# Altium schematic sheet Analog Input.SchDoc
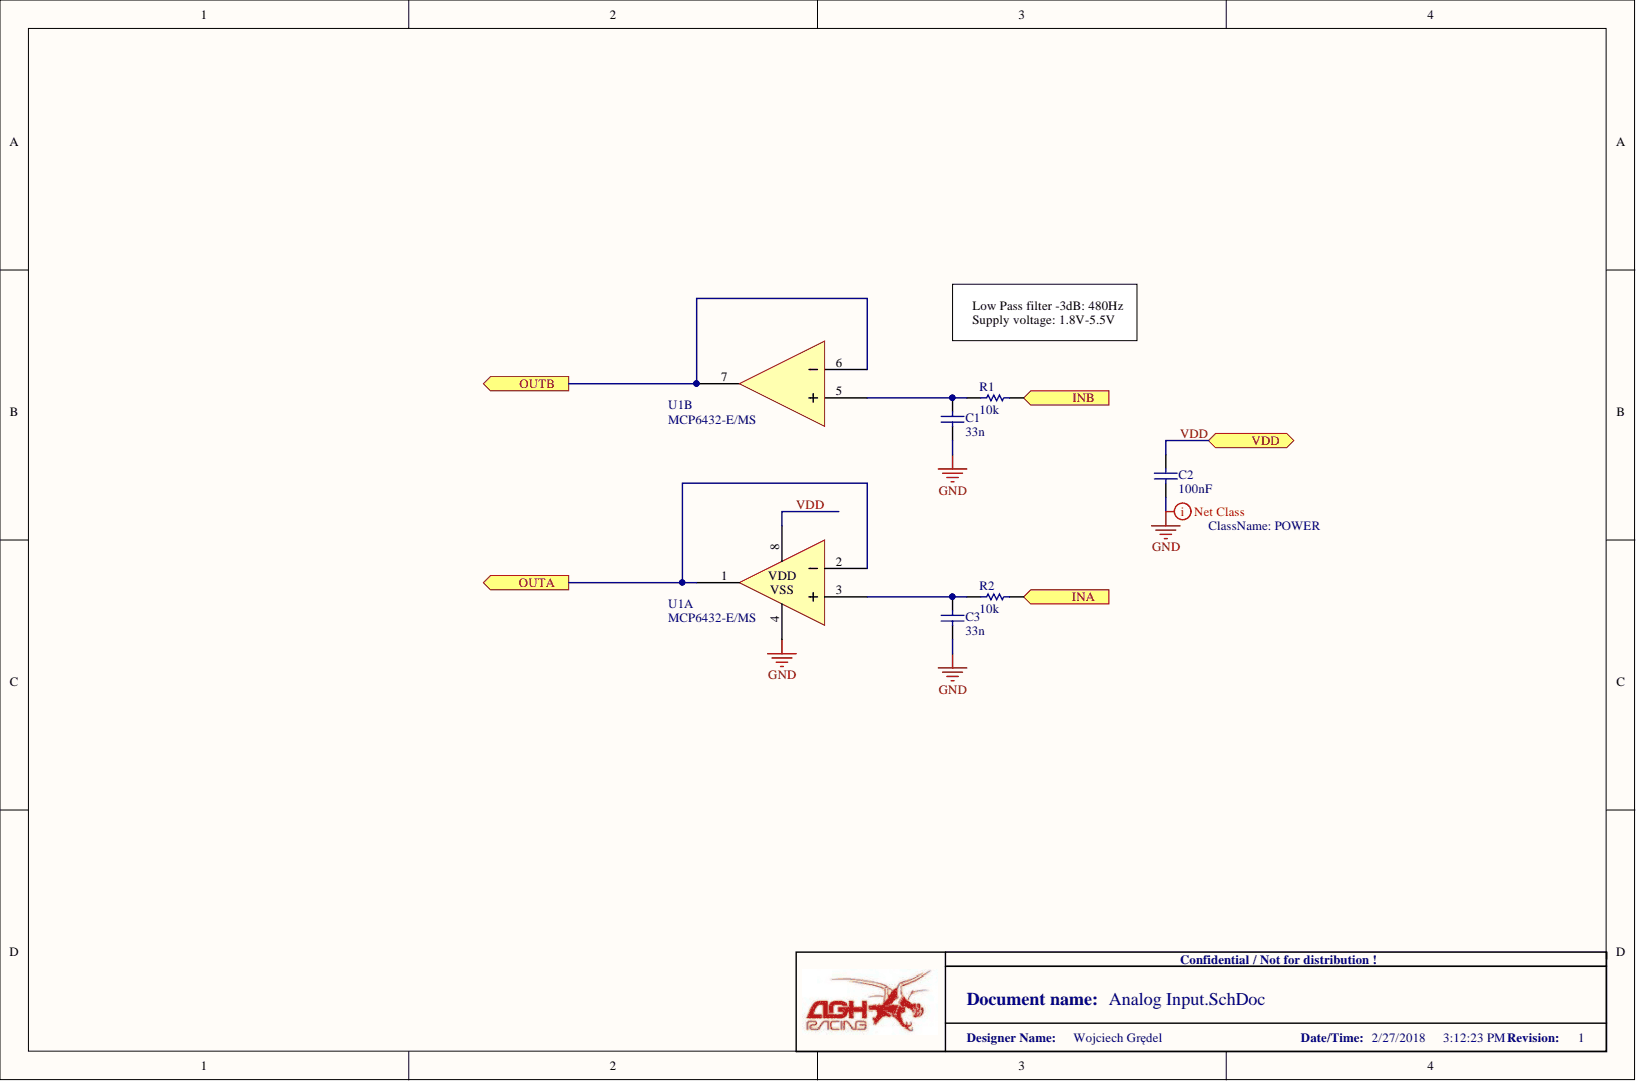
[diagram p0: Analog Input.SchDoc]

## Parts
C1  value 33n  [CAP_0603_IPC_HD]  (hidden comment: Cap)
C2  value 100nF  [CAP_0603_IPC_HD]  (hidden comment: Cap)
C3  value 33n  [CAP_0603_IPC_HD]  (hidden comment: Cap)
R1  value 10k  [RES_0603_IPC_HD]  (hidden comment: Res)
R2  value 10k  [RES_0603_IPC_HD]  (hidden comment: Res)
U1  MCP6432-E/MS  [MSOP8_IPC_MD]  (parts A,B)

## Ports
INA, INB, OUTA, OUTB, VDD

## Nets
GND: C1.1 C2.1 C3.1 U1.4
INA: R2.2 ; port INA
INB: R1.2 ; port INB
OUTA: U1.1 U1.2 ; port OUTA
OUTB: U1.6 U1.7 ; port OUTB
VDD: C2.2 U1.8 ; port VDD
NetC1_2: C1.2 R1.1 U1.5
NetC3_2: C3.2 R2.1 U1.3

## Library data of the parts
C1-C3 = Cap: Capacitor; Component Kind: Standard; Library Name: agh_racing_2018.SchLib
R1 R2 = Res: Resistor; Component Kind: Standard; Library Name: agh_racing_2018.SchLib
U1 = MCP6432-E/MS: Component Kind: Standard; Library Name: agh_racing_2018.SchLib
